# Altium schematic sheet [08] - QSFP28_2.SchDoc
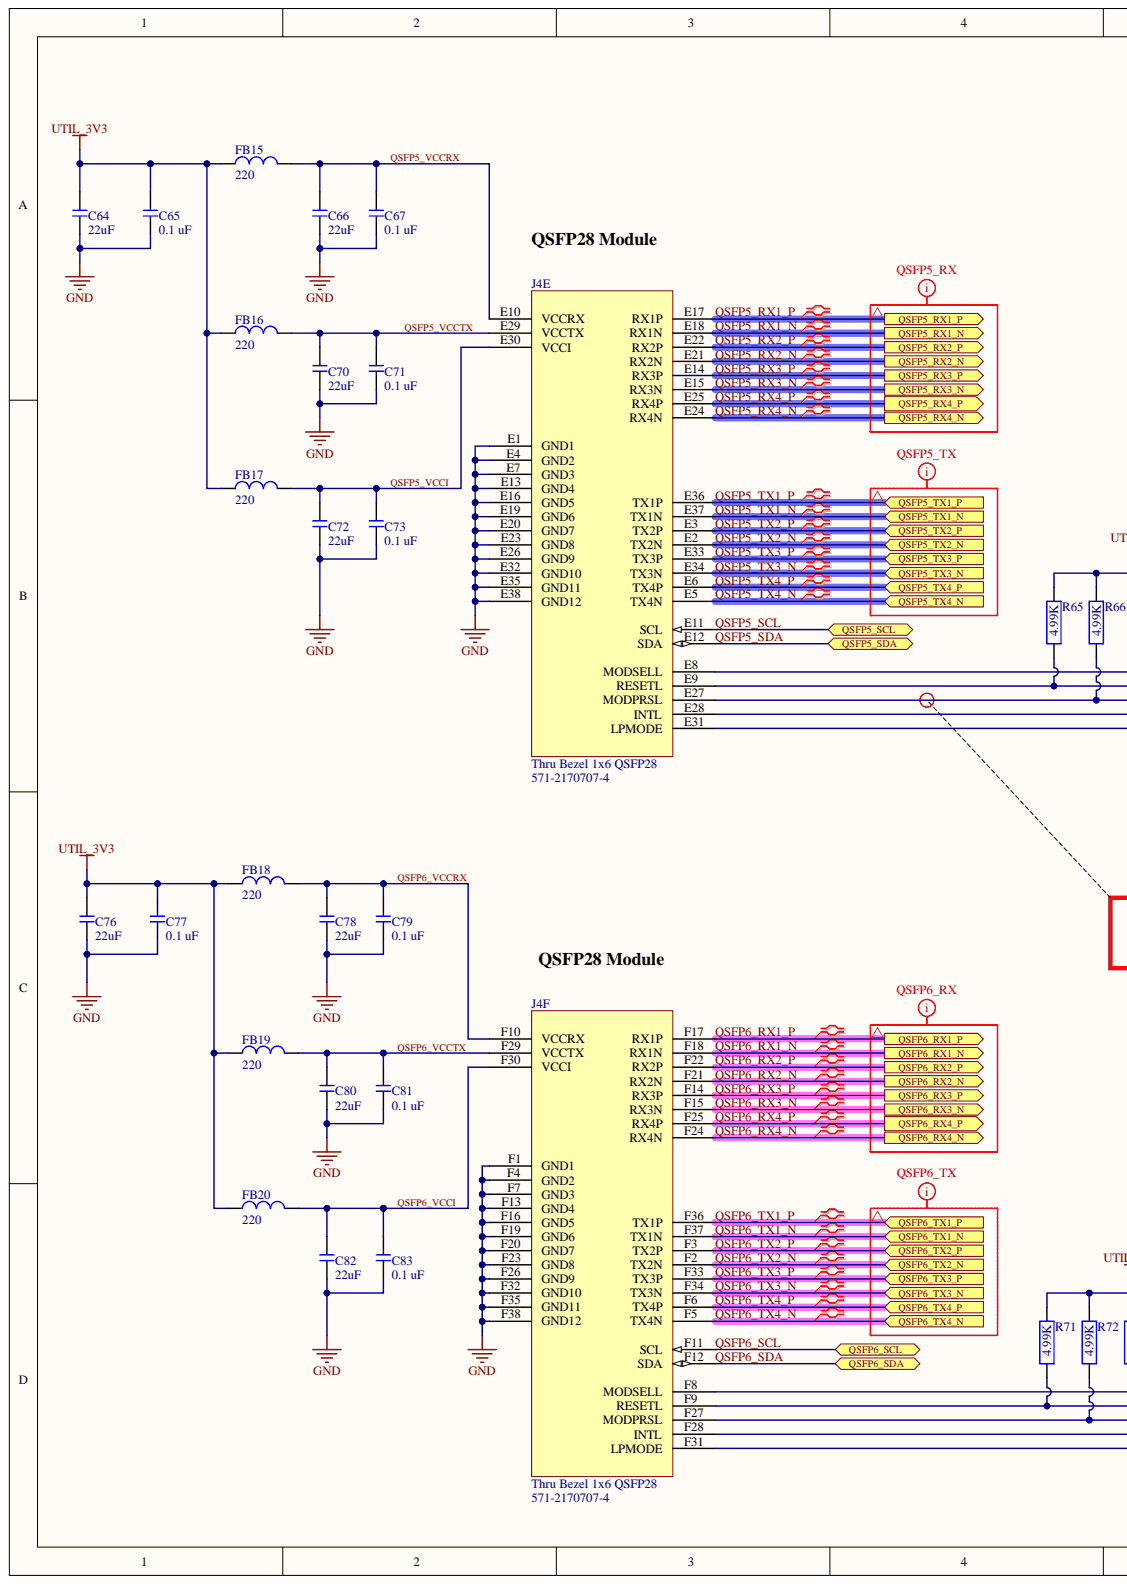
[diagram p0: [08] - QSFP28_2.SchDoc, left]
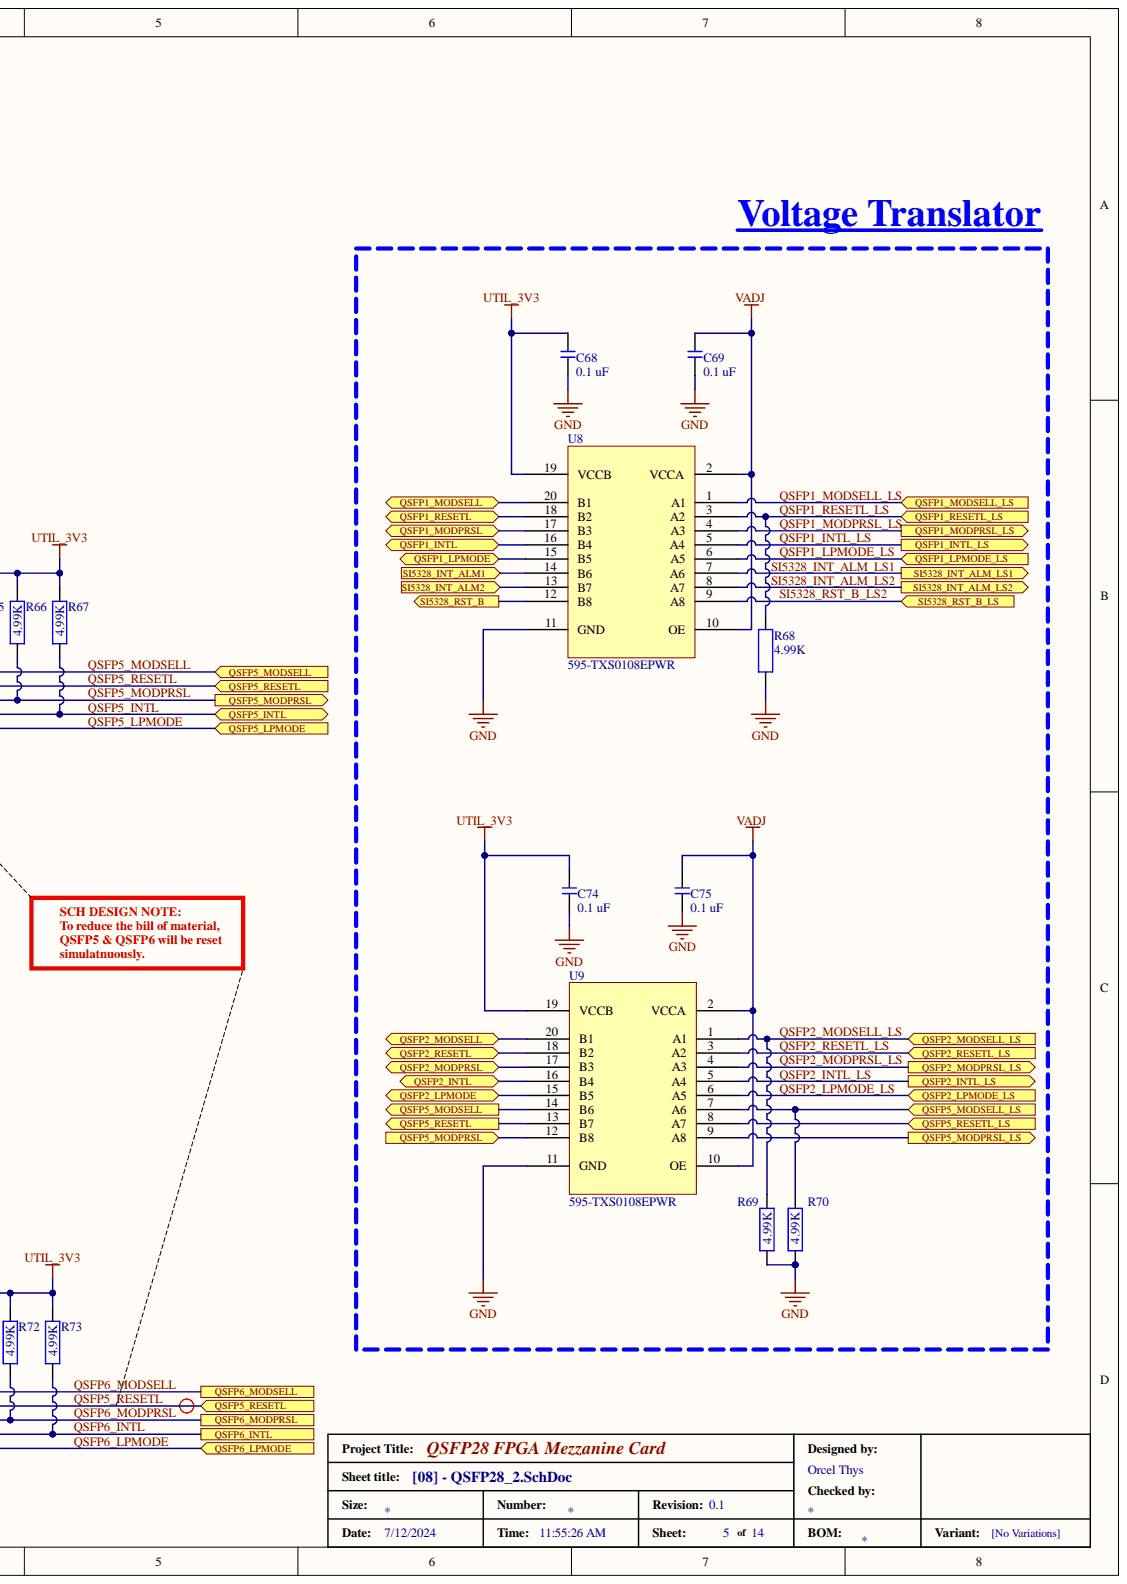
[diagram p1: [08] - QSFP28_2.SchDoc, right]

## Parts
C64  22uF  [C2012]
C65  0.1 uF  [C0402]
C66  22uF  [C2012]
C67  0.1 uF  [C0402]
C68  0.1 uF  [C0402]
C69  0.1 uF  [C0402]
C70  22uF  [C2012]
C71  0.1 uF  [C0402]
C72  22uF  [C2012]
C73  0.1 uF  [C0402]
C74  0.1 uF  [C0402]
C75  0.1 uF  [C0402]
C76  22uF  [C2012]
C77  0.1 uF  [C0402]
C78  22uF  [C2012]
C79  0.1 uF  [C0402]
C80  22uF  [C2012]
C81  0.1 uF  [C0402]
C82  22uF  [C2012]
C83  0.1 uF  [C0402]
FB15  220  [BEADC1608X75N]
FB16  220  [BEADC1608X75N]
FB17  220  [BEADC1608X75N]
FB18  220  [BEADC1608X75N]
FB19  220  [BEADC1608X75N]
FB20  220  [BEADC1608X75N]
J4  Thru Bezel 1x6 QSFP28  Supplier Part Number 1: 571-2170707-4  [TE_2170707-4]  (parts E,F)
R65  4.99K  [R0402]
R66  4.99K  [R0402]
R67  4.99K  [R0402]
R68  4.99K  [R0402]
R69  4.99K  [R0402]
R70  4.99K  [R0402]
R71  4.99K  [R0402]
R72  4.99K  [R0402]
R73  4.99K  [R0402]
U8  Supplier Part Number 1: 595-TXS0108EPWR  [SOP_65P640X120-20N]  (hidden comment: 8-bit voltage translator)
U9  Supplier Part Number 1: 595-TXS0108EPWR  [SOP_65P640X120-20N]  (hidden comment: 8-bit voltage translator)

## Ports
QSFP1_INTL, QSFP1_INTL_LS, QSFP1_LPMODE, QSFP1_LPMODE_LS, QSFP1_MODPRSL, QSFP1_MODPRSL_LS, QSFP1_MODSELL, QSFP1_MODSELL_LS, QSFP1_RESETL, QSFP1_RESETL_LS, QSFP2_INTL, QSFP2_INTL_LS, QSFP2_LPMODE, QSFP2_LPMODE_LS, QSFP2_MODPRSL, QSFP2_MODPRSL_LS, QSFP2_MODSELL, QSFP2_MODSELL_LS, QSFP2_RESETL, QSFP2_RESETL_LS, QSFP5_INTL, QSFP5_LPMODE, QSFP5_MODPRSL, QSFP5_MODPRSL_LS, QSFP5_MODSELL, QSFP5_MODSELL_LS, QSFP5_RESETL, QSFP5_RESETL_LS, QSFP5_RX1_N, QSFP5_RX1_P, QSFP5_RX2_N, QSFP5_RX2_P, QSFP5_RX3_N, QSFP5_RX3_P, QSFP5_RX4_N, QSFP5_RX4_P, QSFP5_SCL, QSFP5_SDA, QSFP5_TX1_N, QSFP5_TX1_P, QSFP5_TX2_N, QSFP5_TX2_P, QSFP5_TX3_N, QSFP5_TX3_P, QSFP5_TX4_N, QSFP5_TX4_P, QSFP6_INTL, QSFP6_LPMODE, QSFP6_MODPRSL, QSFP6_MODSELL, QSFP6_RX1_N, QSFP6_RX1_P, QSFP6_RX2_N, QSFP6_RX2_P, QSFP6_RX3_N, QSFP6_RX3_P, QSFP6_RX4_N, QSFP6_RX4_P, QSFP6_SCL, QSFP6_SDA, QSFP6_TX1_N, QSFP6_TX1_P, QSFP6_TX2_N, QSFP6_TX2_P, QSFP6_TX3_N, QSFP6_TX3_P, QSFP6_TX4_N, QSFP6_TX4_P, SI5328_INT_ALM1, SI5328_INT_ALM2, SI5328_INT_ALM_LS1, SI5328_INT_ALM_LS2, SI5328_RST_B, SI5328_RST_B_LS

## Nets
GND: C64.1 C65.1 C66.1 C67.1 C68.2 C69.1 C70.1 C71.1 C72.1 C73.1 C74.1 C75.1 C76.1 C77.1 C78.1 C79.1 C80.1 C81.1 C82.1 C83.1 J4.E1(GND1) J4.E4(GND2) J4.E7(GND3) J4.E13(GND4) J4.E16(GND5) J4.E19(GND6) J4.E20(GND7) J4.E23(GND8) J4.E26(GND9) J4.E32(GND10) J4.E35(GND11) J4.E38(GND12) J4.F1(GND1) J4.F4(GND2) J4.F7(GND3) J4.F13(GND4) J4.F16(GND5) J4.F19(GND6) J4.F20(GND7) J4.F23(GND8) J4.F26(GND9) J4.F32(GND10) J4.F35(GND11) J4.F38(GND12) R68.1 R69.1 R70.1 U8.11(GND) U9.11(GND)
UTIL_3V3: C64.2 C65.2 C68.1 C74.2 C76.2 C77.2 FB15.1 FB16.1 FB17.1 FB18.1 FB19.1 FB20.1 R65.2 R66.2 R67.2 R71.2 R72.2 R73.2 U8.19(VCCB) U9.19(VCCB)
VADJ: C69.2 C75.2 U8.2(VCCA) U8.10(OE) U9.2(VCCA) U9.10(OE)
QSFP1_INTL: U8.16(B4) ; port QSFP1_INTL
QSFP1_INTL_LS: U8.5(A4) ; port QSFP1_INTL_LS
QSFP1_LPMODE: U8.15(B5) ; port QSFP1_LPMODE
QSFP1_LPMODE_LS: U8.6(A5) ; port QSFP1_LPMODE_LS
QSFP1_MODPRSL: U8.17(B3) ; port QSFP1_MODPRSL
QSFP1_MODPRSL_LS: U8.4(A3) ; port QSFP1_MODPRSL_LS
QSFP1_MODSELL: U8.20(B1) ; port QSFP1_MODSELL
QSFP1_MODSELL_LS: U8.1(A1) ; port QSFP1_MODSELL_LS
QSFP1_RESETL: U8.18(B2) ; port QSFP1_RESETL
QSFP1_RESETL_LS: R68.2 U8.3(A2) ; port QSFP1_RESETL_LS
QSFP2_INTL: U9.16(B4) ; port QSFP2_INTL
QSFP2_INTL_LS: U9.5(A4) ; port QSFP2_INTL_LS
QSFP2_LPMODE: U9.15(B5) ; port QSFP2_LPMODE
QSFP2_LPMODE_LS: U9.6(A5) ; port QSFP2_LPMODE_LS
QSFP2_MODPRSL: U9.17(B3) ; port QSFP2_MODPRSL
QSFP2_MODPRSL_LS: U9.4(A3) ; port QSFP2_MODPRSL_LS
QSFP2_MODSELL: U9.20(B1) ; port QSFP2_MODSELL
QSFP2_MODSELL_LS: R69.2 U9.1(A1) ; port QSFP2_MODSELL_LS
QSFP2_RESETL: U9.18(B2) ; port QSFP2_RESETL
QSFP2_RESETL_LS: U9.3(A2) ; port QSFP2_RESETL_LS
QSFP5_INTL: J4.E28(INTL) R67.1 ; port QSFP5_INTL
QSFP5_LPMODE: J4.E31(LPMODE) ; port QSFP5_LPMODE
QSFP5_MODPRSL: J4.E27(MODPRSL) R66.1 U9.12(B8) ; port QSFP5_MODPRSL
QSFP5_MODPRSL_LS: U9.9(A8) ; port QSFP5_MODPRSL_LS
QSFP5_MODSELL: J4.E8(MODSELL) U9.14(B6) ; port QSFP5_MODSELL
QSFP5_MODSELL_LS: R70.2 U9.7(A6) ; port QSFP5_MODSELL_LS
QSFP5_RESETL: J4.E9(RESETL) J4.F9(RESETL) R65.1 R71.1 U9.13(B7) ; port QSFP5_RESETL
QSFP5_RESETL_LS: U9.8(A7) ; port QSFP5_RESETL_LS
QSFP5_RX1_N: J4.E18(RX1N) ; port QSFP5_RX1_N
QSFP5_RX1_P: J4.E17(RX1P) ; port QSFP5_RX1_P
QSFP5_RX2_N: J4.E21(RX2N) ; port QSFP5_RX2_N
QSFP5_RX2_P: J4.E22(RX2P) ; port QSFP5_RX2_P
QSFP5_RX3_N: J4.E15(RX3N) ; port QSFP5_RX3_N
QSFP5_RX3_P: J4.E14(RX3P) ; port QSFP5_RX3_P
QSFP5_RX4_N: J4.E24(RX4N) ; port QSFP5_RX4_N
QSFP5_RX4_P: J4.E25(RX4P) ; port QSFP5_RX4_P
QSFP5_SCL: J4.E11(SCL) ; port QSFP5_SCL
QSFP5_SDA: J4.E12(SDA) ; port QSFP5_SDA
QSFP5_TX1_N: J4.E37(TX1N) ; port QSFP5_TX1_N
QSFP5_TX1_P: J4.E36(TX1P) ; port QSFP5_TX1_P
QSFP5_TX2_N: J4.E2(TX2N) ; port QSFP5_TX2_N
QSFP5_TX2_P: J4.E3(TX2P) ; port QSFP5_TX2_P
QSFP5_TX3_N: J4.E34(TX3N) ; port QSFP5_TX3_N
QSFP5_TX3_P: J4.E33(TX3P) ; port QSFP5_TX3_P
QSFP5_TX4_N: J4.E5(TX4N) ; port QSFP5_TX4_N
QSFP5_TX4_P: J4.E6(TX4P) ; port QSFP5_TX4_P
QSFP5_VCCI: C72.2 C73.2 FB17.2 J4.E30(VCCI)
QSFP5_VCCRX: C66.2 C67.2 FB15.2 J4.E10(VCCRX)
QSFP5_VCCTX: C70.2 C71.2 FB16.2 J4.E29(VCCTX)
QSFP6_INTL: J4.F28(INTL) R73.1 ; port QSFP6_INTL
QSFP6_LPMODE: J4.F31(LPMODE) ; port QSFP6_LPMODE
QSFP6_MODPRSL: J4.F27(MODPRSL) R72.1 ; port QSFP6_MODPRSL
QSFP6_MODSELL: J4.F8(MODSELL) ; port QSFP6_MODSELL
QSFP6_RX1_N: J4.F18(RX1N) ; port QSFP6_RX1_N
QSFP6_RX1_P: J4.F17(RX1P) ; port QSFP6_RX1_P
QSFP6_RX2_N: J4.F21(RX2N) ; port QSFP6_RX2_N
QSFP6_RX2_P: J4.F22(RX2P) ; port QSFP6_RX2_P
QSFP6_RX3_N: J4.F15(RX3N) ; port QSFP6_RX3_N
QSFP6_RX3_P: J4.F14(RX3P) ; port QSFP6_RX3_P
QSFP6_RX4_N: J4.F24(RX4N) ; port QSFP6_RX4_N
QSFP6_RX4_P: J4.F25(RX4P) ; port QSFP6_RX4_P
QSFP6_SCL: J4.F11(SCL) ; port QSFP6_SCL
QSFP6_SDA: J4.F12(SDA) ; port QSFP6_SDA
QSFP6_TX1_N: J4.F37(TX1N) ; port QSFP6_TX1_N
QSFP6_TX1_P: J4.F36(TX1P) ; port QSFP6_TX1_P
QSFP6_TX2_N: J4.F2(TX2N) ; port QSFP6_TX2_N
QSFP6_TX2_P: J4.F3(TX2P) ; port QSFP6_TX2_P
QSFP6_TX3_N: J4.F34(TX3N) ; port QSFP6_TX3_N
QSFP6_TX3_P: J4.F33(TX3P) ; port QSFP6_TX3_P
QSFP6_TX4_N: J4.F5(TX4N) ; port QSFP6_TX4_N
QSFP6_TX4_P: J4.F6(TX4P) ; port QSFP6_TX4_P
QSFP6_VCCI: C82.2 C83.2 FB20.2 J4.F30(VCCI)
QSFP6_VCCRX: C78.2 C79.2 FB18.2 J4.F10(VCCRX)
QSFP6_VCCTX: C80.2 C81.2 FB19.2 J4.F29(VCCTX)
SI5328_INT_ALM1: U8.14(B6) ; port SI5328_INT_ALM1
SI5328_INT_ALM2: U8.13(B7) ; port SI5328_INT_ALM2
SI5328_INT_ALM_LS1: U8.7(A6) ; port SI5328_INT_ALM_LS1
SI5328_INT_ALM_LS2: U8.8(A7) ; port SI5328_INT_ALM_LS2
SI5328_RST_B: U8.12(B8) ; port SI5328_RST_B
SI5328_RST_B_LS2: U8.9(A8) ; port SI5328_RST_B_LS

## Library data of the parts
C64 C66 C70 C72 C76 C78 C80 C82 = C2012X5R1V226M125AC: Cap Series 0805 22 uF 35 V ±20 % Tolerance X5R SMT Multilayer Ceramic Capacitor; Manufacturer: TDK; Tolerance: 20%; Voltage Rating: 35V; Capacitance: 22uF; Case Code (Imperial): 0805; Case Code (Metric): 2012; Case/Package: 0805; Category: Ceramic Capacitors; Component Kind: Standard; ComponentLink1Description: https://datasheet.ciiva.com/14893/tdkmlcccaprange-14893109.pdf?src-supplier=Digi-Key; ComponentLink1URL: https://datasheet.ciiva.com/14893/tdkmlcccaprange-14893109.pdf?src-supplier=Digi-Key; ComponentLink2Description: https://datasheet.ciiva.com/14893/tdkmlcccaprange-14893110.pdf?src-supplier=Digi-Key; ComponentLink2URL: https://datasheet.ciiva.com/14893/tdkmlcccaprange-14893110.pdf?src-supplier=Digi-Key; ComponentLink3Description: https://datasheet.ciiva.com/14893/tdkmlcccaprange-14893111.pdf?src-supplier=Digi-Key; ComponentLink3URL: https://datasheet.ciiva.com/14893/tdkmlcccaprange-14893111.pdf?src-supplier=Digi-Key; Dielectric: X5R; Dielectric Material: Ceramic; Height: 1.25mm; Lead Free: Lead Free; Length: 0.079inch; Manufacturer Part Number: C2012X5R1V226M125AC; Max Operating Temperature: 85°C; Min Operating Temperature: -55°C; Mount: Surface Mount; Package Quantity: 1; Packaging: Tape and Reel; Pricing 1: 50=0.544, 100=0.502, 250=0.458, 500=0.418 (EUR); Reach SVHC Compliant: No; RoHS: Yes; RoHS Compliant: Yes; Series: C; Stock 1: 15560; Supplier 1: Rs; Supplier Part Number 1: 9159334P; Termination: SMD/SMT; Thickness: 0.057inch; Voltage Rating (DC): 35V; Weight: 0.000194oz; Width: 0.049inch; Library Name: QSFP28_FMC_lib.SchLib
C65 C67-C69 C71 C73-C75 C77 C79 C81 C83 = UMK105B7104KV-FR: Cap 0402 0.1 uF 50 V ±10 % Tolerance X7R Surface Mount Multilayer Ceramic Capacitor; Manufacturer: Taiyo Yuden; Capacitance: 100nF; Tolerance: 10%; Voltage Rating: 50V; Case Code (Imperial): 0402; Case Code (Metric): 1005; Case/Package: 0402; Category: Ceramic Capacitors; Component Kind: Standard; ComponentLink1Description: https://datasheet.ciiva.com/24356/619umk105b7104kv-fr-24356845.pdf?src-supplier=Onlinecomponents.com; ComponentLink1URL: https://datasheet.ciiva.com/24356/619umk105b7104kv-fr-24356845.pdf?src-supplier=Onlinecomponents.com; ComponentLink2Description: https://datasheet.ciiva.com/30180/52umk105b7104kv-fr-30180098.pdf?src-supplier=Onlinecomponents.com; ComponentLink2URL: https://datasheet.ciiva.com/30180/52umk105b7104kv-fr-30180098.pdf?src-supplier=Onlinecomponents.com; ComponentLink3Description: https://datasheet.ciiva.com/18697/mlcc-all-e-18697452.pdf?src-supplier=Digi-Key; ComponentLink3URL: https://datasheet.ciiva.com/18697/mlcc-all-e-18697452.pdf?src-supplier=Digi-Key; ComponentLink4Description: https://datasheet.ciiva.com/18697/mlcc-all-e-18697451.pdf?src-supplier=Digi-Key; ComponentLink4URL: https://datasheet.ciiva.com/18697/mlcc-all-e-18697451.pdf?src-supplier=Digi-Key; ComponentLink5Description: https://datasheet.ciiva.com/18697/mlcc-all-e-18697453.pdf?src-supplier=Digi-Key; ComponentLink5URL: https://datasheet.ciiva.com/18697/mlcc-all-e-18697453.pdf?src-supplier=Digi-Key; Dielectric: X7R; Halogen Free: Halogen Free; Height: 0.5mm; Lead Free: Lead Free; Length: 0.039inch; Manufacturer Part Number: UMK105B7104KV-FR; Max Operating Temperature: 125°C; Min Operating Temperature: -55°C; Mount: Surface Mount; Package Quantity: 1; Packaging: Tape and Reel; Pricing 1: 10000=0.016 (EUR); RoHS: Yes; RoHS Compliant: Yes; Series: M; Stock 1: 60000; Supplier 1: Rs; Supplier Part Number 1: 1782869; Termination: SMD/SMT; Thickness: 0.022inch; Voltage Rating (DC): 50V; Width: 0.02inch; Library Name: QSFP28_FMC_lib.SchLib
FB15-FB20 = MPZ1608S221ATA00: 0603 220Ω ±25% DCR=0.050Ω 2.2A; Manufacturer: TDK; Core Material: Ferrite; DC Resistance (DCR): 50mR; Impedance: 220R; Number of Lines: 1; Case Code (Imperial): 0603; Case Code (Metric): 1608; Case/Package: 0603; Category: Ferrite Beads and Chips; Component Kind: Standard; ComponentLink1Description: https://datasheet.ciiva.com/8077/55167-8077073.pdf?src-supplier=Element14; ComponentLink1URL: https://datasheet.ciiva.com/8077/55167-8077073.pdf?src-supplier=Element14; ComponentLink2Description: https://datasheet.ciiva.com/8077/55167-8077071.pdf?src-supplier=Element14; ComponentLink2URL: https://datasheet.ciiva.com/8077/55167-8077071.pdf?src-supplier=Element14; ComponentLink3Description: https://datasheet.ciiva.com/46381/1486324-46381628.pdf?src-supplier=Element14; ComponentLink3URL: https://datasheet.ciiva.com/46381/1486324-46381628.pdf?src-supplier=Element14; ComponentLink4Description: https://datasheet.ciiva.com/46366/print-detail-pdf-phplangenpart-nompz1608s221ata00nreimp-unit-xmhz-46366044.pdf?src-supplier=Onlinecomponents.com; ComponentLink4URL: https://datasheet.ciiva.com/46366/print-detail-pdf-phplangenpart-nompz1608s221ata00nreimp-unit-xmhz-46366044.pdf?src-supplier=Onlinecomponents.com; Current Rating: 2.2A; Height: 0.037inch; Lead Free: Lead Free; Length: 0.063inch; Manufacturer Part Number: MPZ1608S221ATA00; Max Current Rating: 2.2A; Max DC Current: 2.2A; Mount: Surface Mount; Number of Pins: 2; Package Quantity: 1; Packaging: Cut Tape; Pricing 1: 4000=0.0235, 20000=0.023, 40000=0.0226 (EUR); Reach SVHC Compliant: No; RoHS: Yes; RoHS Compliant: Yes; Series: MPZ; Stock 1: 256000; Supplier 1: Farnell; Supplier Part Number 1: 2800773; Tolerance: 25%; Width: 0.031inch; Library Name: QSFP28_FMC_lib.SchLib
J4 = 2170707-4: Cage Assy, 1X6, QSFP28, Spring, Hs; Manufacturer: TE Connectivity AMP; Category: Connectors; Component Kind: Standard; ComponentLink1Description: https://www.te.com/commerce/DocumentDelivery/DDEController?Action=srchrtrv&DocNm=2170707&DocType=Customer+Drawing&DocLang=English&src-supplier=Digi-Key; ComponentLink1URL: https://www.te.com/commerce/DocumentDelivery/DDEController?Action=srchrtrv&DocNm=2170707&DocType=Customer+Drawing&DocLang=English&src-supplier=Digi-Key; Manufacturer Part Number: 2170707-4; Pricing 1: 1=80.77, 10=80.77, 50=71.27, 100=70.28, 1000=67.22, 10000=67.22 (USD); RoHS: Yes; Stock 1: 60; Supplier 1: Mouser; Library Name: QSFP28_FMC_lib.SchLib
R65-R73 = ERJ-2RKF4991X: RES SMD 4.99K OHM 1% 1/10W 0402; Manufacturer: Panasonic; Max Power Dissipation: 100mW; Resistance: 4.99kR; Temperature Coefficient: 100ppm/°C; Voltage Rating: 50V; Case Code (Imperial): 0402; Case Code (Metric): 1005; Case/Package: 0402; Category: Chip SMD Resistors; Component Kind: Standard; ComponentLink1Description: https://datasheet.ciiva.com/46388/426256-46388311.pdf?src-supplier=Element14; ComponentLink1URL: https://datasheet.ciiva.com/46388/426256-46388311.pdf?src-supplier=Element14; ComponentLink2Description: https://datasheet.ciiva.com/46376/426256-46376955.pdf?src-supplier=Element14; ComponentLink2URL: https://datasheet.ciiva.com/46376/426256-46376955.pdf?src-supplier=Element14; ComponentLink3Description: https://datasheet.ciiva.com/22749/1678782-22749654.pdf?src-supplier=Element14; ComponentLink3URL: https://datasheet.ciiva.com/22749/1678782-22749654.pdf?src-supplier=Element14; ComponentLink4Description: https://datasheet.ciiva.com/24158/aoa0000ce2-24158871.pdf?src-supplier=Mouser; ComponentLink4URL: https://datasheet.ciiva.com/24158/aoa0000ce2-24158871.pdf?src-supplier=Mouser; ComponentLink5Description: https://datasheet.ciiva.com/16775/70260748-16775018.pdf?src-supplier=Allied+Electronics; ComponentLink5URL: https://datasheet.ciiva.com/16775/70260748-16775018.pdf?src-supplier=Allied+Electronics; ComponentLink6Description: https://datasheet.ciiva.com/16773/70260748-16773110.pdf?src-supplier=Allied+Electronics; ComponentLink6URL: https://datasheet.ciiva.com/16773/70260748-16773110.pdf?src-supplier=Allied+Electronics; ComponentLink7Description: https://datasheet.ciiva.com/16199/aoa0000ce2-16199546.pdf?src-supplier=Future+Electronics; ComponentLink7URL: https://datasheet.ciiva.com/16199/aoa0000ce2-16199546.pdf?src-supplier=Future+Electronics; Construction: Rectangular; Contact Plating: Tin; Depth: 0.5mm; Features: Automotive AEC-Q200; Height: 0.016inch; Lead Free: Lead Free; Length: 1mm; Manufacturer Part Number: ERJ-2RKF4991X; Max Operating Temperature: 155°C; Military Standard: Not; Min Operating Temperature: -55°C; Mount: Surface Mount; Number of Terminations: 2; Package Quantity: 1; Packaging: Tape and Reel; Power Rating: 100mW; Pricing 1: 1=0.1, 10=0.018, 50=0.018, 100=0.008, 1000=0.006, 10000=0.004 (USD); Radiation Hardening: No; Reach SVHC Compliant: No; Resistance Tolerance: 1%; Resistor Type: Thick Film; RoHS: Yes; RoHS Compliant: Yes; Series: ERJ; Stock 1: 1218727; Supplier 1: Mouser; Supplier Part Number 1: 667-ERJ-2RKF4991X; Technology: THICK FILM; Termination: SMD/SMT; Tolerance: 1%; Voltage Rating (DC): 50V; Weight: 2.8E-05oz; Width: 0.5mm; Working Voltage: 50V; Library Name: QSFP28_FMC_lib.SchLib
U8 U9 = TXS0108EPWR: IC 8BIT NON-INV TRANSLTR 20TSSOP; Manufacturer: Texas Instruments; Channel Type: Bidirectional; Data Rate: 60Mbps; High Level Output Current: -50mA; Low Level Output Current: 50mA; Max Supply Voltage: 5.5V; Min Supply Voltage: 1.2V; Number of Bits: 8; Number of Circuits: 1; Output Current: 620uA; Propagation Delay: 4.8ns; Quiescent Current: 3uA; Rise Time: 6.3ns; Supply Current: 8uA; Turn-On Delay Time: 312ns; Case/Package: TSSOP; Category: Voltage Level Shifters; Component Kind: Standard; ComponentLink1Description: https://datasheet.ciiva.com/14287/txs0108e-14287280.pdf?src-supplier=Farnell; ComponentLink1URL: https://datasheet.ciiva.com/14287/txs0108e-14287280.pdf?src-supplier=Farnell; ComponentLink2Description: https://datasheet.ciiva.com/28653/1714661-28653429.pdf?src-supplier=Element14; ComponentLink2URL: https://datasheet.ciiva.com/28653/1714661-28653429.pdf?src-supplier=Element14; ComponentLink3Description: https://datasheet.ciiva.com/4834/sces642b-127094-4834401.pdf?src-supplier=Mouser; ComponentLink3URL: https://datasheet.ciiva.com/4834/sces642b-127094-4834401.pdf?src-supplier=Mouser; ComponentLink4Description: https://datasheet.ciiva.com/15096/getdatasheetpartid-322119-15096276.pdf?src-supplier=Verical; ComponentLink4URL: https://datasheet.ciiva.com/15096/getdatasheetpartid-322119-15096276.pdf?src-supplier=Verical; ComponentLink5Description: https://datasheet.ciiva.com/1508/1815244-1508732.pdf?src-supplier=Element14; ComponentLink5URL: https://datasheet.ciiva.com/1508/1815244-1508732.pdf?src-supplier=Element14; ComponentLink6Description: https://datasheet.ciiva.com/1899/txs0108e-1899605.pdf?src-supplier=Digi-Key; ComponentLink6URL: https://datasheet.ciiva.com/1899/txs0108e-1899605.pdf?src-supplier=Digi-Key; ComponentLink7Description: https://datasheet.ciiva.com/1899/txs0108e-1899604.pdf?src-supplier=Digi-Key; ComponentLink7URL: https://datasheet.ciiva.com/1899/txs0108e-1899604.pdf?src-supplier=Digi-Key; ComponentLink8Description: https://datasheet.ciiva.com/1899/txs0108e-1899606.pdf?src-supplier=Digi-Key; ComponentLink8URL: https://datasheet.ciiva.com/1899/txs0108e-1899606.pdf?src-supplier=Digi-Key; ComponentLink9Description: https://datasheet.ciiva.com/14287/txs0108e-14287532.pdf?src-supplier=Avnet; ComponentLink9URL: https://datasheet.ciiva.com/14287/txs0108e-14287532.pdf?src-supplier=Avnet; Lead Free: Lead Free; Logic Function: Translator; Manufacturer Part Number: TXS0108EPWR; Max Operating Temperature: 85°C; Min Operating Temperature: -40°C; Mount: Surface Mount; Number of Channels: 8; Number of Inputs: 8; Number of Pins: 20; Package Quantity: 2000; Packaging: Tape and Reel; Pricing 1: 1=1.57, 10=1.41, 50=1.33, 100=1.13, 1000=0.772, 10000=0.702 (USD); Radiation Hardening: No; Reach SVHC Compliant: No; RoHS: Yes; RoHS Compliant: Yes; Series: -; Stock 1: 220045; Supplier 1: Mouser; Termination: SMD/SMT; Weight: 0.002716oz; Library Name: FmcLib.SchLib
